# Altium schematic sheet CP2102_SCH.SchDoc
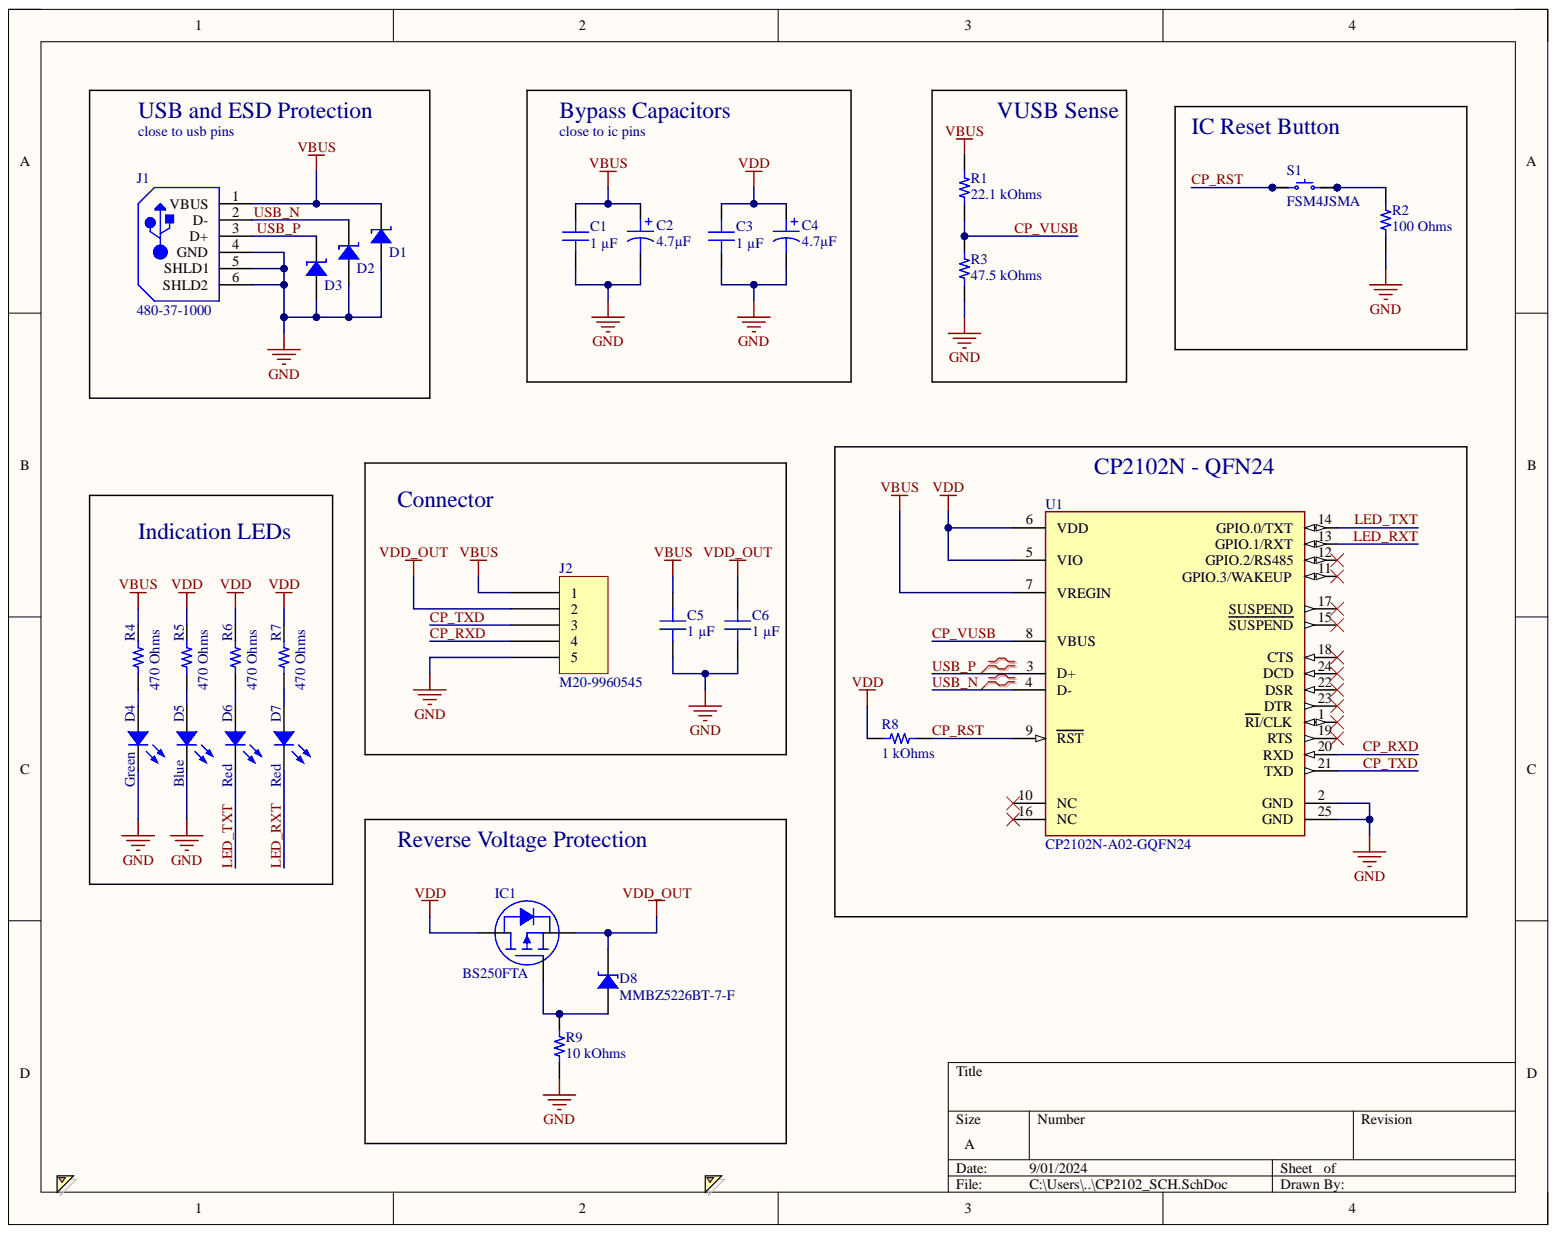
[diagram p0: CP2102_SCH.SchDoc]

## Parts
C1  1 µF  [CAP 0402_1005]
C2  4.7µF  [CAP POL 1206_3216]
C3  1 µF  [CAP 0402_1005]
C4  4.7µF  [CAP POL 1206_3216]
C5  1 µF  [CAP 0402_1005]
C6  1 µF  [CAP 0402_1005]
D1  UCLAMP5031PW.C  [0402]  (value not drawn)
D2  UCLAMP5031PW.C  [0402]  (value not drawn)
D3  UCLAMP5031PW.C  [0402]  (value not drawn)
D4  Green  [LED 0402_1005 GREEN]
D5  Blue  [LED 0402_1005 BLUE]
D6  Red  [LED 0402_1005 RED]
D7  Red  [LED 0402_1005 RED]
D8  MMBZ5226BT-7-F  [DIODES SOT-523]
IC1  BS250FTA  [DIODES SOT-23-3]
J1  480-37-1000  [MOLX-480-37-1000_V]
J2  M20-9960545  [HARWIN M20-9960545]
R1  22.1 kOhms  [RES 0402_1005]
R2  100 Ohms  [RES 0402_1005]
R3  47.5 kOhms  [RES 0402_1005]
R4  470 Ohms  [RES 0402_1005]
R5  470 Ohms  [RES 0402_1005]
R6  470 Ohms  [RES 0402_1005]
R7  470 Ohms  [RES 0402_1005]
R8  1 kOhms  [RES 0402_1005]
R9  10 kOhms  [RES 0402_1005]
S1  FSM4JSMA  [TE FSM4JSMA]
U1  CP2102N-A02-GQFN24  [FP-CP2102N-A02-GQFN24-MFG]

## Nets
GND: C1.1 C2.2 C3.1 C4.2 C5.1 C6.1 D1.1 D2.1 D3.1 D4.2 D5.2 J1.4(GND) J1.5(SHLD1) J1.6(SHLD2) J2.5 R2.1 R3.1 R9.1 U1.2(GND) U1.25(GND)
VBUS: C1.2 C2.1 C5.2 D1.2 J1.1(VBUS) J2.1 R1.2 R4.2 U1.7(VREGIN)
VDD: C3.2 C4.1 IC1.3 R5.2 R6.2 R7.2 R8.1 U1.5(VIO) U1.6(VDD)
VDD_OUT: C6.2 D8.2 IC1.2 J2.2
CP_RST: R8.2 S1.1 S1.2 U1.9(RST)
CP_RXD: J2.4 U1.20(RXD)
CP_TXD: J2.3 U1.21(TXD)
CP_VUSB: R1.1 R3.2 U1.8(VBUS)
LED_RXT: D7.2 U1.13(GPIO.1/RXT)
LED_TXT: D6.2 U1.14(GPIO.0/TXT)
USB_N: D2.2 J1.2(D-) U1.4(D-)
USB_P: D3.2 J1.3(D+) U1.3(D+)
NetD4_1: D4.1 R4.1
NetD5_1: D5.1 R5.1
NetD6_1: D6.1 R6.1
NetD7_1: D7.1 R7.1
NetD8_1: D8.1 IC1.1 R9.2
NetR2_2: R2.2 S1.3 S1.4

Not connected: U1 1(RI/CLK) 10(NC) 11(GPIO.3/WAKEUP) 12(GPIO.2/RS485) 15(SUSPEND) 16(NC) 17(SUSPEND) 18(CTS) 19(RTS) 22(DSR) 23(DTR) 24(DCD)

## Library data of the parts
C1 C3 C5 C6 = GRM155C71A105KE11D: CAP CER 1UF 10V X7S 0402; manufacturer: Murata Electronics; Database Table Name: Capacitors - Ceramic - 0402; Tolerance: ±10%; Applications: General Purpose; Capacitance: 1 µF; Component Kind: Standard; componentlink1description: Datasheet; componentlink1url: https://search.murata.co.jp/Ceramy/image/img/A01X/G101/ENG/GRM155C71A105KE11-01.pdf; componentlink2description: DigiKey Link; componentlink2url: https://www.digikey.com/en/products/detail/murata-electronics/GRM155C71A105KE11D/6606086; Device Package: 0402 (1005 Metric); lastupdated: 2/9/2024 8:04:38 PM; Library Name: Celestial Altium Library - altium_library.DbLib; Lifecycle Status: Active; Minimum Order: 1; Mounting Type: Surface Mount, MLCC; Operating Temperature: -55°C ~ 125°C; Package / Case: 0402 (1005 Metric); packaging: TapeAndReel; Part Number: GRM155C71A105KE11D; Part Status: Active; partid: 1749; price: 0.01; series: GRM; Size / Dimension: 0.039" L x 0.020" W (1.00mm x 0.50mm); Supplier 1: DigiKey; Supplier Part Number 1: 490-14457-1-ND; Temperature Coefficient: X7S; Thickness (Max): 0.024" (0.60mm); Value: 1 µF; Voltage - Rated: 10V
C2 C4 = TAJA475K025RNJ: CAP TANT 4.7UF 10% 25V 1206; manufacturer: AVX Corporation; Manufacturer Size Code: A; Database Table Name: Capacitors - Tantalum; ESR (Equivalent Series Resistance): 3.1Ohm; Type: Molded; Capacitance: 4.7µF; Component Kind: Standard; componentlink1description: Datasheet; componentlink1url: http://datasheets.avx.com/TAJ.pdf; componentlink2description: DigiKey Link; componentlink2url: http://digikey.com/product-detail/en/avx-corporation/TAJA475K025RNJ/478-11053-1-ND/7536161; Device Package: 1206 (3216 Metric); Features: General Purpose; Height - Seated (Max): 0.071" (1.80mm); lastupdated: 9/2/2020 1:15:57 AM; Library Name: Celestial Altium Library - altium_library.DbLib; Lifecycle Status: Active; Minimum Order: 1; Mounting Type: Surface Mount; Operating Temperature: -55°C ~ 125°C; Package / Case: 1206 (3216 Metric); packaging: TapeAndReel; Part Number: TAJA475K025RNJ; Part Status: Active; partid: 763; price: 0.10; series: TAJ; Size / Dimension: 0.126" L x 0.063" W (3.20mm x 1.60mm); Supplier 1: DigiKey; Supplier Part Number 1: 478-11053-1-ND; Tolerance: ±10%; Value: 4.7µF; Voltage - Rated: 25V
D1-D3 = UCLAMP5031PW.C: 5V ESD & EOS PROTECTION; Manufacturer: Semtech Corporation; Database Table Name: Diodes - TVS; Power Line Protection: No; Type: Zener; Unidirectional Channels: 1; Applications: General Purpose; Component Kind: Standard; ComponentLink2Description: DigiKey Link; ComponentLink2URL: https://www.digikey.com/en/products/detail/semtech-corporation/UCLAMP5031PW-C/21371847; Device Package: 0402 (1005 Metric); LastUpdated: 6/3/2024 8:22:49 PM; Library Name: Celestial Altium Library - altium_library.DbLib; Lifecycle Status: Active; Minimum Order: 1; Mounting Type: Surface Mount; Package / Case: 0402 (1005 Metric); Packaging: TapeAndReel; Part Number: UCLAMP5031PW.C; PartId: 11945; Price: 0.08; Series: uClamp®; Supplier 1: DigiKey; Supplier Device Package: 2-DFN (1x0.6); Supplier Part Number 1: 600-UCLAMP5031PW.CCT-ND; Voltage - Reverse Standoff (Typ): 5V
D4 = 150040GS73220: LED GREEN CLEAR 0402 SMD; Manufacturer: Würth Elektronik; Configuration: 0402; Current - Test: 20mA; Database Table Name: LED - Indication; Lens Color: Colorless; Lens Size: 1.00mm x 0.50mm; Lens Style: Rectangle with Flat Top; Millicandela Rating: 750mcd; Viewing Angle: 120°; Voltage - Forward (Vf) (Typ): 3.3V; Wavelength - Dominant: 525nm; Wavelength - Peak: 520nm; Color: Green; Component Kind: Standard; ComponentLink2Description: DigiKey Link; ComponentLink2URL: https://www.digikey.com/en/products/detail/würth-elektronik/150040GS73220/9857949; Device Package: 0402 (1005 Metric); Height (Max): 0.35mm; LastUpdated: 6/3/2024 7:58:14 PM; Lens Transparency: Clear; Library Name: Celestial Altium Library - altium_library.DbLib; Lifecycle Status: Active; Minimum Order: 1; Mounting Type: Surface Mount; Package / Case: 0402 (1005 Metric); Packaging: TapeAndReel; Part Number: 150040GS73220; Part Status: Active; PartId: 708; Price: 0.29; Series: WL-SMCC; Size / Dimension: 1.00mm L x 0.50mm W; Supplier 1: DigiKey; Supplier Device Package: 0402; Supplier Part Number 1: 732-13426-1-ND; Value: Green
D5 = 150040BS73240: LED BLUE CLEAR 0402 SMD; Manufacturer: Würth Elektronik; Configuration: Standard; Current - Test: 20mA; Database Table Name: LED - Indication; Lens Color: Colorless; Lens Size: 1.00mm x 0.50mm; Lens Style: Rectangle with Flat Top; Millicandela Rating: 150mcd; Viewing Angle: 120°; Voltage - Forward (Vf) (Typ): 3.2V; Wavelength - Dominant: 470nm; Wavelength - Peak: 465nm; Color: Blue; Component Kind: Standard; ComponentLink1Description: Datasheet; ComponentLink1URL: https://katalog.we-online.de/led/datasheet/150040BS73240.pdf; ComponentLink2Description: DigiKey Link; ComponentLink2URL: https://www.digikey.com/en/products/detail/würth-elektronik/150040BS73240/8557154; Device Package: 0402 (1005 Metric); Height (Max): 0.50mm; LastUpdated: 6/3/2024 7:57:32 PM; Lens Transparency: Clear; Library Name: Celestial Altium Library - altium_library.DbLib; Lifecycle Status: Active; Minimum Order: 1; Mounting Type: Surface Mount; Package / Case: 0402 (1005 Metric); Packaging: TapeAndReel; Part Number: 150040BS73240; Part Status: Active; PartId: 858; Price: 0.16; Series: WL-SMCC; Size / Dimension: 1.00mm L x 0.50mm W; Supplier 1: DigiKey; Supplier Device Package: 0402 (1005 Metric); Supplier Part Number 1: 732-11989-1-ND; Value: Blue
D6 D7 = 150040SS73220: LED RED CLEAR 0402 SMD; Manufacturer: Würth Elektronik; Configuration: 0402; Current - Test: 20mA; Database Table Name: LED - Indication; Lens Color: Colorless; Lens Size: 1.00mm x 0.50mm; Lens Style: Rectangle with Flat Top; Millicandela Rating: 90mcd; Viewing Angle: 120°; Voltage - Forward (Vf) (Typ): 2V; Wavelength - Dominant: 630nm; Wavelength - Peak: 645nm; Color: Red; Component Kind: Standard; ComponentLink2Description: DigiKey Link; ComponentLink2URL: https://www.digikey.com/en/products/detail/würth-elektronik/150040SS73220/9857944; Device Package: 0402 (1005 Metric); Height (Max): 0.35mm; LastUpdated: 6/3/2024 7:59:17 PM; Lens Transparency: Clear; Library Name: Celestial Altium Library - altium_library.DbLib; Lifecycle Status: Active; Minimum Order: 1; Mounting Type: Surface Mount; Package / Case: 0402 (1005 Metric); Packaging: TapeAndReel; Part Number: 150040SS73220; Part Status: Active; PartId: 511; Price: 0.29; Series: WL-SMCC; Size / Dimension: 1.00mm L x 0.50mm W; Supplier 1: DigiKey; Supplier Device Package: 0402; Supplier Part Number 1: 732-13421-1-ND; Value: Red
D8 = MMBZ5226BT-7-F: DIODE ZENER 3.3V 150MW SOT523; Manufacturer: Diodes Incorporated; Current - Reverse Leakage @ Vr: 25 µA @ 1 V; Database Table Name: Diodes - Zener - Single; Impedance (Max) (Zzt): 28 Ohms; Tolerance: ±5%; Voltage - Forward (Vf) (Max) @ If: 900 mV @ 10 mA; Component Kind: Standard; ComponentLink1Description: Datasheet; ComponentLink1URL: https://www.diodes.com/assets/Datasheets/ds30267.pdf; ComponentLink2Description: DigiKey Link; ComponentLink2URL: https://www.digikey.com/en/products/detail/diodes-incorporated/MMBZ5226BT-7-F/2095654; LastUpdated: 6/3/2024 8:01:51 PM; Library Name: Celestial Altium Library - altium_library.DbLib; Lifecycle Status: Active; Minimum Order: 1; Mounting Type: Surface Mount; Operating Temperature: -65°C ~ 150°C; Package / Case: SOT-523; Packaging: TapeAndReel; Part Number: MMBZ5226BT-7-F; Part Status: Active; PartId: 790; Power - Max: 150 mW; Price: 0.05; Series: -; Supplier 1: DigiKey; Supplier Device Package: SOT-523; Supplier Part Number 1: MMBZ5226BTDICT-ND; Voltage - Zener (Nom) (Vz): 3.3 V
IC1 = BS250FTA: MOSFET P-CH 45V 90MA SOT23-3; Manufacturer: Diodes Incorporated; Current - Continuous Drain (Id) @ 25°C: 90mA (Ta); Database Table Name: MOSFET - Single - P-Ch; Drive Voltage (Max Rds On,  Min Rds On): 10V; FET Type: P-Channel; Input Capacitance (Ciss) (Max) @ Vds: 25 pF @ 10 V; Power Dissipation (Max): 330mW (Ta); Rds On (Max) @ Id, Vgs: 14Ohm @ 200mA, 10V; Vgs (Max): ±20V; Vgs(th) (Max) @ Id: 3.5V @ 1mA; Component Kind: Standard; ComponentLink1Description: Datasheet; ComponentLink1URL: https://www.diodes.com/assets/Datasheets/BS250F.pdf; ComponentLink2Description: DigiKey Link; ComponentLink2URL: https://www.digikey.com/en/products/detail/diodes-incorporated/BS250FTA/58819; Drain to Source Voltage (Vdss): 45 V; LastUpdated: 6/3/2024 8:15:20 PM; Library Name: Celestial Altium Library - altium_library.DbLib; Lifecycle Status: Active; Minimum Order: 1; Mounting Type: Surface Mount; Operating Temperature: -55°C ~ 150°C (TJ); Package / Case: TO-236-3, SC-59, SOT-23-3; Packaging: TapeAndReel; Part Number: BS250FTA; Part Status: Active; Price: 0.22; Series: -; Supplier 1: DigiKey; Supplier Device Package: SOT-23-3; Supplier Part Number 1: BS250FCT-ND; Technology: MOSFET (Metal Oxide)
J1 = CMP-1676-00005-2: Universal Serial Bus (USB) Shielded I/O Plug, Type A, Right Angle Connector, 1.5 A, -20 to 85 degC, 4-Pin SMD, RoHS, Tray; Case\Package: 0603; Power Rating: 100 mW; Resistance: 1 mohm; Tolerance: 1%; Voltage Rating: 50 V; Case Code (Imperial): 0603; Case Code (Metric): 1608; Component Kind: Standard; ComponentLink1Description: Manufacturer URL; ComponentLink1URL: http://www.molex.com/; ComponentLink2Description: Datasheet; ComponentLink2URL: http://www.molex.com/pdm_docs/sd/480371000_sd.pdf; DatasheetVersion: Rev. D, 06/2004; Height: 0.45 mm; Length: 1.6 mm; Library Name: Altium Content Vault; Max Operating Temperature: 155 degC; Min Operating Temperature: -55 degC; Mount: Surface Mount; Package Quantity: 5000; PackageDescription: 4-Pin Surface Mount Device, Body 12 x 18.8 mm; PackageReference: 480-37-1000; Packaging: Tape and Reel; Pins: 2; REACH SVHC: No SVHC; RoHSCompliant: true; Voltage Rating (DC): 50 V; Width: 0.8 mm
J2 = M20-9960545: CONN HEADER R/A 5POS 2.54MM; Manufacturer: Harwin Inc.; Connector Type: Header, Cuttable; Contact Length - Mating: 0.240" (6.10mm); Contact Length - Post: 0.118" (3.00mm); Current Rating (Amps): 3A per Contact; Database Table Name: Connectors - Rectangular Headers Male Pins; Material Flammability Rating: UL94 V-0; Number of Positions: 5; Number of Positions Loaded: All; Pitch - Mating: 0.100" (2.54mm); Row Spacing - Mating: -40°C ~ 105°C; Shrouding: Unshrouded; Style: Board to Board or Cable; Component Kind: Standard; ComponentLink1Description: Datasheet; ComponentLink1URL: https://cdn.harwin.com/pdfs/Harwin_PC_Connectors_M20.pdf; ComponentLink2Description: DigiKey Link; ComponentLink2URL: https://www.digikey.com/en/products/detail/harwin-inc/M20-9960545/3728210; Contact Finish - Mating: Gold; Contact Material: Brass; Contact Shape: Square; Contact Type: Male Pin; Fastening Type: Push-Pull; Insulation Color: Black; Insulation Height: 0.100" (2.54mm); Insulation Material: Polyamide (PA6T), Nylon 6T; LastUpdated: 9/21/2022 7:23:36 PM; Library Name: Celestial Altium Library - altium_library.DbLib; Lifecycle Status: Active; Minimum Order: 1; Mounting Type: Through Hole, Right Angle; Number of Rows: 1; Operating Temperature: -40°C ~ 105°C; Packaging: Bulk; Part Number: M20-9960545; Part Status: Active; PartId: 168; Price: 0.14; Series: M20; Supplier 1: DigiKey; Supplier Part Number 1: 952-2246-ND; Termination: Solder
R1 = CRCW040222K1FKEDC: RES 22.1K OHM 1% 1/16W 0402; Manufacturer: Vishay Dale; Database Table Name: Resistors - Surface Mount - 0402; Power (Watts): 0.063W, 1/16W; Temperature Coefficient: ±100ppm/°C; Component Kind: Standard; ComponentLink1Description: Datasheet; ComponentLink1URL: http://www.vishay.com/docs/28773/crcwce3.pdf; ComponentLink2Description: DigiKey Link; ComponentLink2URL: https://www.digikey.com/en/products/detail/vishay-dale/CRCW040222K1FKEDC/7928510; Composition: Thick Film; Device Package: 0402 (1005 Metric); Height - Seated (Max): 0.014" (0.35mm); LastUpdated: 2/9/2024 8:29:38 PM; Library Name: Celestial Altium Library - altium_library.DbLib; Lifecycle Status: Active; Minimum Order: 1; Number of Terminations: 2; Operating Temperature: -55°C ~ 155°C; Package / Case: 0402 (1005 Metric); Packaging: CutTape; Part Number: CRCW040222K1FKEDC; Part Status: Active; PartId: 16090; Price: 0.01; Resistance: 22.1 kOhms; Series: CRCW-C; Size / Dimension: 0.039" L x 0.020" W (1.00mm x 0.50mm); Supplier 1: DigiKey; Supplier Device Package: 0402; Supplier Part Number 1: 541-4088-1-ND; Tolerance: ±1%; Value: 22.1 kOhms
R2 = MCS0402PD1000DE500: RES 100 OHM 0.5% 1/5W 0402; Manufacturer: Vishay Beyschlag; Database Table Name: Resistors - Surface Mount - 0402; Power (Watts): 0.2W, 1/5W; Temperature Coefficient: ±25ppm/°C; Component Kind: Standard; ComponentLink1Description: Datasheet; ComponentLink1URL: http://www.vishay.com/docs/28916/mcxhp.pdf; ComponentLink2Description: DigiKey Link; ComponentLink2URL: http://digikey.com/product-detail/en/vishay-beyschlag/MCS0402PD1000DE500/749-1509-1-ND/7245027; Composition: Thin Film; Device Package: 0402 (1005 Metric); Features: Anti-Sulfur, Automotive AEC-Q200; Height - Seated (Max): 0.015" (0.37mm); LastUpdated: 2/9/2024 8:30:24 PM; Library Name: Celestial Altium Library - altium_library.DbLib; Lifecycle Status: Active; Minimum Order: 1; Number of Terminations: 2; Operating Temperature: -55°C ~ 175°C; Package / Case: 0402 (1005 Metric); Packaging: TapeAndReel; Part Number: MCS0402PD1000DE500; Part Status: Active; PartId: 2456; Price: 0.08; Ratings: AEC-Q200; Resistance: 100 Ohms; Series: MCS HP; Size / Dimension: 0.039" L x 0.020" W (1.00mm x 0.50mm); Supplier 1: DigiKey; Supplier Device Package: 0402; Supplier Part Number 1: 749-1509-1-ND; Tolerance: ±0.5%; Value: 100 Ohms
R3 = RCS040247K5FKED: RES SMD 47.5K OHM 1% 1/5W 0402; Manufacturer: Vishay Dale; Database Table Name: Resistors - Surface Mount - 0402; Power (Watts): 0.2W, 1/5W; Temperature Coefficient: ±100ppm/°C; Component Kind: Standard; ComponentLink1Description: Datasheet; ComponentLink1URL: http://www.vishay.com/docs/20065/rcse3.pdf; ComponentLink2Description: DigiKey Link; ComponentLink2URL: https://www.digikey.com/en/products/detail/vishay-dale/RCS040247K5FKED/5867879; Composition: Thick Film; Device Package: 0402 (1005 Metric); Features: Automotive AEC-Q200, Pulse Withstanding; Height - Seated (Max): 0.016" (0.40mm); LastUpdated: 2/9/2024 8:30:08 PM; Library Name: Celestial Altium Library - altium_library.DbLib; Lifecycle Status: Active; Minimum Order: 1; Number of Terminations: 2; Operating Temperature: -55°C ~ 155°C; Package / Case: 0402 (1005 Metric); Packaging: TapeAndReel; Part Number: RCS040247K5FKED; Part Status: Active; PartId: 6045; Price: 0.01; Ratings: AEC-Q200; Resistance: 47.5 kOhms; Series: RCS e3; Size / Dimension: 0.039" L x 0.020" W (1.00mm x 0.50mm); Supplier 1: DigiKey; Supplier Device Package: 0402; Supplier Part Number 1: 541-10413-1-ND; Tolerance: ±1%; Value: 47.5 kOhms
R4-R7 = TNPW0402470RBYEP: RES 470 OHM 0.1% 1/16W 0402; Manufacturer: Vishay Dale; Database Table Name: Resistors - Surface Mount - 0402; Power (Watts): 0.1W, 1/10W; Temperature Coefficient: ±10ppm/°C; Component Kind: Standard; ComponentLink1Description: Datasheet; ComponentLink1URL: http://www.vishay.com/docs/28758/tnpw_e3.pdf; ComponentLink2Description: DigiKey Link; ComponentLink2URL: http://digikey.com/product-detail/en/vishay-dale/TNPW0402470RBYEP/541-9810-1-ND/9562435; Composition: Thin Film; Device Package: 0402 (1005 Metric); Features: Anti-Sulfur, Automotive AEC-Q200, Moisture Resistant; Height - Seated (Max): 0.016" (0.40mm); LastUpdated: 2/9/2024 8:30:21 PM; Library Name: Celestial Altium Library - altium_library.DbLib; Lifecycle Status: Active; Minimum Order: 1; Number of Terminations: 2; Operating Temperature: -55°C ~ 155°C; Package / Case: 0402 (1005 Metric); Packaging: TapeAndReel; Part Number: TNPW0402470RBYEP; Part Status: Active; PartId: 3068; Price: 0.27; Ratings: AEC-Q200; Resistance: 470 Ohms; Series: TNPW e3; Size / Dimension: 0.039" L x 0.020" W (1.00mm x 0.50mm); Supplier 1: DigiKey; Supplier Device Package: 0402; Supplier Part Number 1: 541-9810-1-ND; Tolerance: ±0.1%; Value: 470 Ohms
R8 = RCA04021K00FKEDHP: RES 1K OHM 1% 1/5W 0402; Manufacturer: Vishay Dale; Database Table Name: Resistors - Surface Mount - 0402; Power (Watts): 0.2W, 1/5W; Temperature Coefficient: ±100ppm/°C; Component Kind: Standard; ComponentLink1Description: Datasheet; ComponentLink1URL: http://www.vishay.com/docs/20067/rcahp.pdf; ComponentLink2Description: DigiKey Link; ComponentLink2URL: https://www.digikey.com/en/products/detail/vishay-dale/RCA04021K00FKEDHP/6561237; Composition: Thick Film; Device Package: 0402 (1005 Metric); Features: Anti-Sulfur, Automotive AEC-Q200, Pulse Withstanding; Height - Seated (Max): 0.016" (0.40mm); LastUpdated: 2/9/2024 8:30:21 PM; Library Name: Celestial Altium Library - altium_library.DbLib; Lifecycle Status: Active; Minimum Order: 1; Number of Terminations: 2; Operating Temperature: -55°C ~ 155°C; Package / Case: 0402 (1005 Metric); Packaging: TapeAndReel; Part Number: RCA04021K00FKEDHP; Part Status: Active; PartId: 3119; Price: 0.02; Ratings: AEC-Q200; Resistance: 1 kOhms; Series: RCA-HP; Size / Dimension: 0.039" L x 0.020" W (1.00mm x 0.50mm); Supplier 1: DigiKey; Supplier Device Package: 0402; Supplier Part Number 1: 541-3240-1-ND; Tolerance: ±1%; Value: 1 kOhms
R9 = TNPW040210K0BETD: RES 10K OHM 0.1% 1/16W 0402; Manufacturer: Vishay Dale; Database Table Name: Resistors - Surface Mount - 0402; Power (Watts): 0.063W, 1/16W; Temperature Coefficient: ±25ppm/°C; Component Kind: Standard; ComponentLink1Description: Datasheet; ComponentLink1URL: http://www.vishay.com/docs/31006/tnpw.pdf; ComponentLink2Description: DigiKey Link; ComponentLink2URL: https://www.digikey.com/en/products/detail/vishay-dale/TNPW040210K0BETD/1613222; Composition: Thin Film; Device Package: 0402 (1005 Metric); Features: Military; Height - Seated (Max): 0.016" (0.40mm); LastUpdated: 2/9/2024 8:30:21 PM; Library Name: Celestial Altium Library - altium_library.DbLib; Lifecycle Status: Active; Minimum Order: 1; Number of Terminations: 2; Operating Temperature: -55°C ~ 125°C; Package / Case: 0402 (1005 Metric); Packaging: TapeAndReel; Part Number: TNPW040210K0BETD; Part Status: Active; PartId: 3021; Price: 0.24; Resistance: 10 kOhms; Series: TNPW; Size / Dimension: 0.039" L x 0.020" W (1.00mm x 0.50mm); Supplier 1: DigiKey; Supplier Device Package: 0402; Supplier Part Number 1: 541-3559-1-ND; Tolerance: ±0.1%; Value: 10 kOhms
S1 = FSM4JSMA: SWITCH TACTILE SPST-NO 0.05A 24V; Manufacturer: TE Connectivity ALCOSWITCH Switches; Actuator Height off PCB, Vertical: 5.00mm; Actuator Orientation: Top Actuated; Actuator Type: Standard; Contact Rating @ Voltage: 0.05A @ 24VDC; Database Table Name: Switches - Tactile; Illumination: Non-Illuminated; Operating Force: 160gf; Outline: 6.00mm x 6.00mm; Switch Function: Off-Mom; Circuit: SPST-NO; Component Kind: Standard; ComponentLink1Description: Datasheet; ComponentLink1URL: https://www.te.com/commerce/DocumentDelivery/DDEController?Action=srchrtrv&DocNm=1308111-1_SWITCHES_CORE_PROGRAM_CATALOG&DocType=CS&DocLang=English; ComponentLink2Description: DigiKey Link; ComponentLink2URL: https://www.digikey.com/en/products/detail/te-connectivity-alcoswitch-switches/FSM4JSMA/525821; LastUpdated: 6/3/2024 8:19:31 PM; Library Name: Celestial Altium Library - altium_library.DbLib; Lifecycle Status: Active; Minimum Order: 1; Mounting Type: Surface Mount; Operating Temperature: -35°C ~ 85°C; Packaging: Bulk; Part Number: FSM4JSMA; Part Status: Active; Price: 0.14; Series: FSMJSMA; Supplier 1: DigiKey; Supplier Part Number 1: 450-1129-ND; Termination Style: Gull Wing
U1 = CMP-08107-000010-1: IC USB TO UART BRIDGE QFN24; Component Kind: Standard; Library Name: Altium Content Vault
